# Altium schematic sheet Cyclone IV E.SchDoc
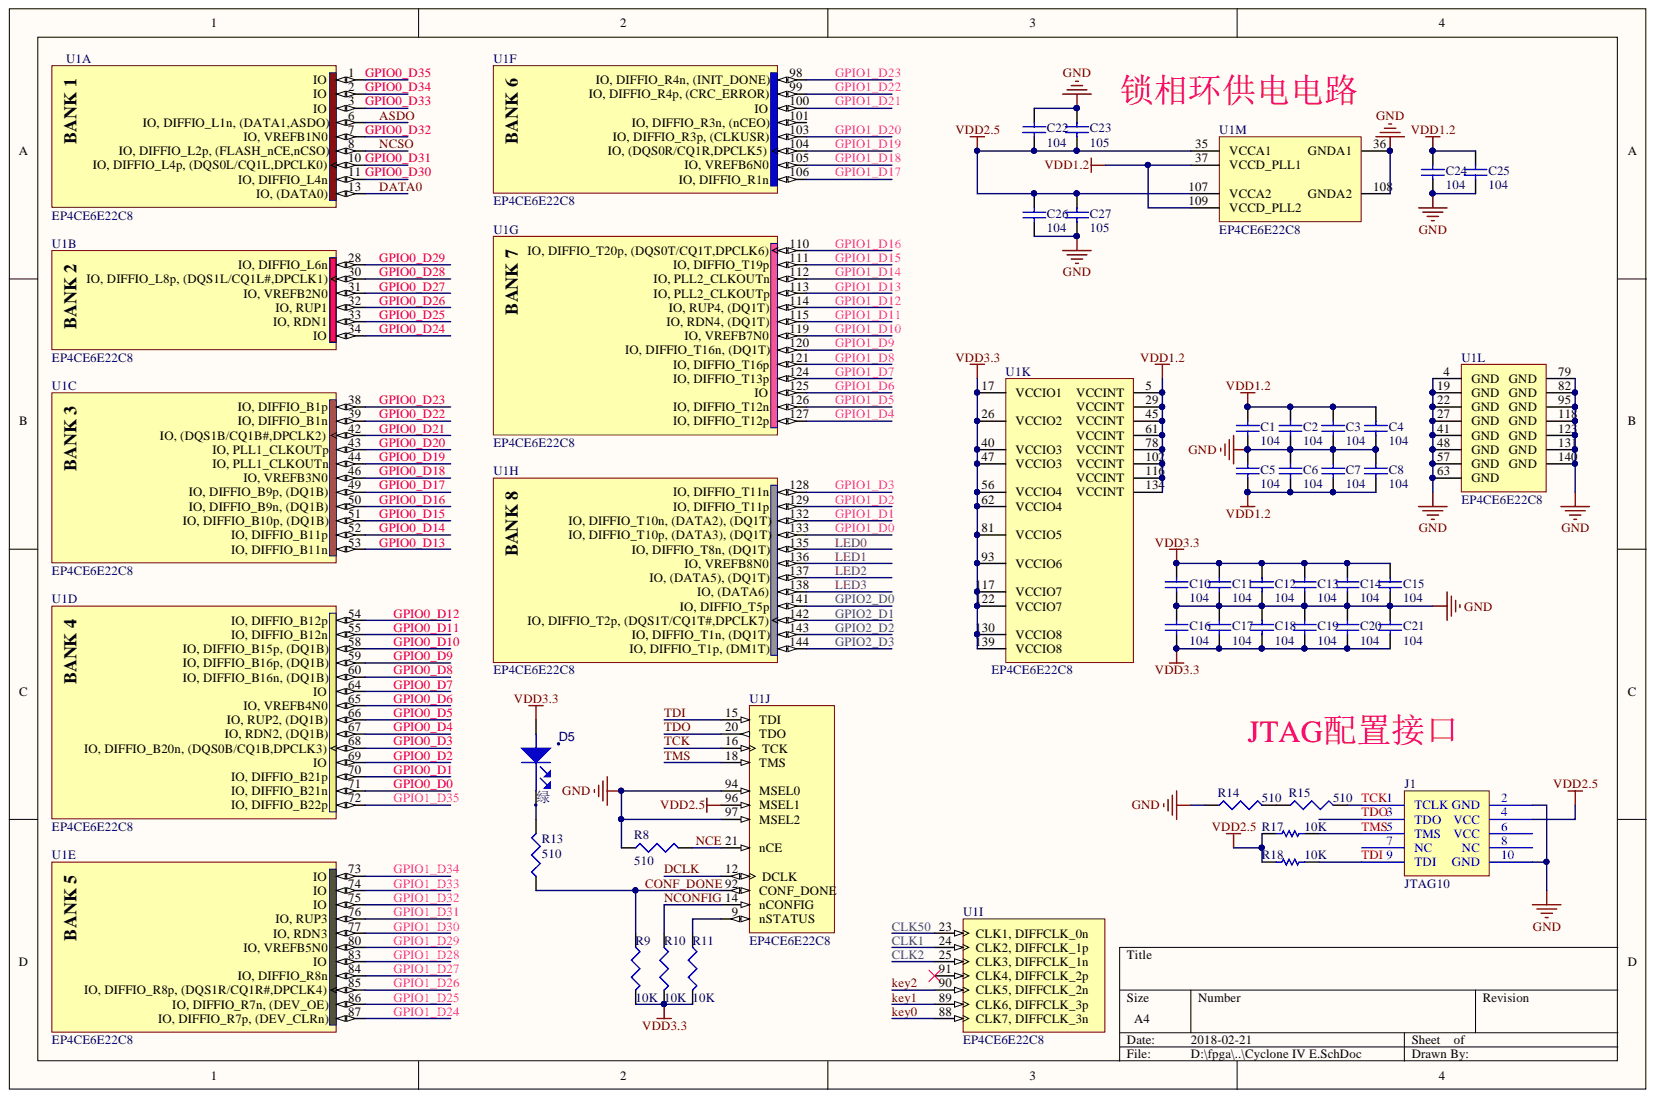
[diagram p0: Cyclone IV E.SchDoc]

## Parts
C1  104  [0603C]
C2  104  [0603C]
C3  104  [0603C]
C4  104  [0603C]
C5  104  [0603C]
C6  104  [0603C]
C7  104  [0603C]
C8  104  [0603C]
C10  104  [0603C]
C11  104  [0603C]
C12  104  [0603C]
C13  104  [0603C]
C14  104  [0603C]
C15  104  [0603C]
C16  104  [0603C]
C17  104  [0603C]
C18  104  [0603C]
C19  104  [0603C]
C20  104  [0603C]
C21  104  [0603C]
C22  104  [0603C]
C23  105  [0603C]
C24  104  [0603C]
C25  104  [0603C]
C26  104  [0603C]
C27  105  [0603C]
D5  绿  [0603 LED]
J1  JTAG10  [JTAG10]
R8  510  [0603R]
R9  10K  [0603R]
R10  10K  [0603R]
R11  10K  [0603R]
R13  510  [0603R]
R14  510  [0603R]
R15  510  [0603R]
R17  10K  [0603R]
R18  10K  [0603R]
U1  EP4CE6E22C8  [QFP_144(0.5PIN间距)]  (parts A,B,C,D,E,F,G,H,I,J,K,L,M)

## Nets
GND: C1.1 C2.1 C3.1 C4.1 C5.2 C6.2 C7.2 C8.2 C10.1 C11.1 C12.1 C13.1 C14.1 C15.1 C16.2 C17.2 C18.2 C19.2 C20.2 C21.2 C22.2 C23.2 C24.1 C25.1 C26.1 C27.1 J1.2(GND) J1.10(GND) R8.1 R14.1 U1.4(GND) U1.19(GND) U1.22(GND) U1.27(GND) U1.36(GNDA1) U1.41(GND) U1.48(GND) U1.57(GND) U1.63(GND) U1.79(GND) U1.82(GND) U1.94(MSEL0) U1.95(GND) U1.97(MSEL2) U1.108(GNDA2) U1.118(GND) U1.123(GND) U1.131(GND) U1.140(GND)
VDD1.2: C1.2 C2.2 C3.2 C4.2 C5.1 C6.1 C7.1 C8.1 C24.2 C25.2 U1.5(VCCINT) U1.29(VCCINT) U1.37(VCCD_PLL1) U1.45(VCCINT) U1.61(VCCINT) U1.78(VCCINT) U1.102(VCCINT) U1.109(VCCD_PLL2) U1.116(VCCINT) U1.134(VCCINT)
VDD2.5: C22.1 C23.1 C26.2 C27.2 J1.4(VCC) R17.1 R18.1 U1.35(VCCA1) U1.96(MSEL1) U1.107(VCCA2)
VDD3.3: C10.2 C11.2 C12.2 C13.2 C14.2 C15.2 C16.1 C17.1 C18.1 C19.1 C20.1 C21.1 D5.1 R9.2 R10.2 R11.2 U1.17(VCCIO1) U1.26(VCCIO2) U1.40(VCCIO3) U1.47(VCCIO3) U1.56(VCCIO4) U1.62(VCCIO4) U1.81(VCCIO5) U1.93(VCCIO6) U1.117(VCCIO7) U1.122(VCCIO7) U1.130(VCCIO8) U1.139(VCCIO8)
ASDO: U1.6(IO,_DIFFIO_L1n,_(DATA1,ASDO))
CLK1: U1.24(CLK2,_DIFFCLK_1p)
CLK2: U1.25(CLK3,_DIFFCLK_1n)
CLK50: U1.23(CLK1,_DIFFCLK_0n)
CONF_DONE: R9.1 R13.1 U1.92(CONF_DONE)
DATA0: U1.13(IO,_(DATA0))
DCLK: U1.12(DCLK)
GPIO0_D0: U1.71(IO,_DIFFIO_B21n)
GPIO0_D1: U1.70(IO,_DIFFIO_B21p)
GPIO0_D2: U1.69(IO)
GPIO0_D3: U1.68(IO,_DIFFIO_B20n,_(DQS0B/CQ1B,DPCLK3))
GPIO0_D4: U1.67(IO,_RDN2,_(DQ1B))
GPIO0_D5: U1.66(IO,_RUP2,_(DQ1B))
GPIO0_D6: U1.65(IO,_VREFB4N0)
GPIO0_D7: U1.64(IO)
GPIO0_D8: U1.60(IO,_DIFFIO_B16n,_(DQ1B))
GPIO0_D9: U1.59(IO,_DIFFIO_B16p,_(DQ1B))
GPIO0_D10: U1.58(IO,_DIFFIO_B15p,_(DQ1B))
GPIO0_D11: U1.55(IO,_DIFFIO_B12n)
GPIO0_D12: U1.54(IO,_DIFFIO_B12p)
GPIO0_D13: U1.53(IO,_DIFFIO_B11n)
GPIO0_D14: U1.52(IO,_DIFFIO_B11p)
GPIO0_D15: U1.51(IO,_DIFFIO_B10p,_(DQ1B))
GPIO0_D16: U1.50(IO,_DIFFIO_B9n,_(DQ1B))
GPIO0_D17: U1.49(IO,_DIFFIO_B9p,_(DQ1B))
GPIO0_D18: U1.46(IO,_VREFB3N0)
GPIO0_D19: U1.44(IO,_PLL1_CLKOUTn)
GPIO0_D20: U1.43(IO,_PLL1_CLKOUTp)
GPIO0_D21: U1.42(IO,_(DQS1B/CQ1B#,DPCLK2))
GPIO0_D22: U1.39(IO,_DIFFIO_B1n)
GPIO0_D23: U1.38(IO,_DIFFIO_B1p)
GPIO0_D24: U1.34(IO)
GPIO0_D25: U1.33(IO,_RDN1)
GPIO0_D26: U1.32(IO,_RUP1)
GPIO0_D27: U1.31(IO,_VREFB2N0)
GPIO0_D28: U1.30(IO,_DIFFIO_L8p,_(DQS1L/CQ1L#,DPCLK1))
GPIO0_D29: U1.28(IO,_DIFFIO_L6n)
GPIO0_D30: U1.11(IO,_DIFFIO_L4n)
GPIO0_D31: U1.10(IO,_DIFFIO_L4p,_(DQS0L/CQ1L,DPCLK0))
GPIO0_D32: U1.7(IO,_VREFB1N0)
GPIO0_D33: U1.3(IO)
GPIO0_D34: U1.2(IO)
GPIO0_D35: U1.1(IO)
GPIO1_D0: U1.133(IO,_DIFFIO_T10p,_(DATA3),_(DQ1T))
GPIO1_D1: U1.132(IO,_DIFFIO_T10n,_(DATA2),_(DQ1T))
GPIO1_D2: U1.129(IO,_DIFFIO_T11p)
GPIO1_D3: U1.128(IO,_DIFFIO_T11n)
GPIO1_D4: U1.127(IO,_DIFFIO_T12p)
GPIO1_D5: U1.126(IO,_DIFFIO_T12n)
GPIO1_D6: U1.125(IO)
GPIO1_D7: U1.124(IO,_DIFFIO_T13p)
GPIO1_D8: U1.121(IO,_DIFFIO_T16p)
GPIO1_D9: U1.120(IO,_DIFFIO_T16n,_(DQ1T))
GPIO1_D10: U1.119(IO,_VREFB7N0)
GPIO1_D11: U1.115(IO,_RDN4,_(DQ1T))
GPIO1_D12: U1.114(IO,_RUP4,_(DQ1T))
GPIO1_D13: U1.113(IO,_PLL2_CLKOUTp)
GPIO1_D14: U1.112(IO,_PLL2_CLKOUTn)
GPIO1_D15: U1.111(IO,_DIFFIO_T19p)
GPIO1_D16: U1.110(IO,_DIFFIO_T20p,_(DQS0T/CQ1T,DPCLK6))
GPIO1_D17: U1.106(IO,_DIFFIO_R1n)
GPIO1_D18: U1.105(IO,_VREFB6N0)
GPIO1_D19: U1.104(IO,_(DQS0R/CQ1R,DPCLK5))
GPIO1_D20: U1.103(IO,_DIFFIO_R3p,_(CLKUSR))
GPIO1_D21: U1.100(IO)
GPIO1_D22: U1.99(IO,_DIFFIO_R4p,_(CRC_ERROR))
GPIO1_D23: U1.98(IO,_DIFFIO_R4n,_(INIT_DONE))
GPIO1_D24: U1.87(IO,_DIFFIO_R7p,_(DEV_CLRn))
GPIO1_D25: U1.86(IO,_DIFFIO_R7n,_(DEV_OE))
GPIO1_D26: U1.85(IO,_DIFFIO_R8p,_(DQS1R/CQ1R#,DPCLK4))
GPIO1_D27: U1.84(IO,_DIFFIO_R8n)
GPIO1_D28: U1.83(IO)
GPIO1_D29: U1.80(IO,_VREFB5N0)
GPIO1_D30: U1.77(IO,_RDN3)
GPIO1_D31: U1.76(IO,_RUP3)
GPIO1_D32: U1.75(IO)
GPIO1_D33: U1.74(IO)
GPIO1_D34: U1.73(IO)
GPIO1_D35: U1.72(IO,_DIFFIO_B22p)
GPIO2_D0: U1.141(IO,_DIFFIO_T5p)
GPIO2_D1: U1.142(IO,_DIFFIO_T2p,_(DQS1T/CQ1T#,DPCLK7))
GPIO2_D2: U1.143(IO,_DIFFIO_T1n,_(DQ1T))
GPIO2_D3: U1.144(IO,_DIFFIO_T1p,_(DM1T))
KEY0: U1.88(CLK7,_DIFFCLK_3n)
KEY1: U1.89(CLK6,_DIFFCLK_3p)
KEY2: U1.90(CLK5,_DIFFCLK_2n)
LED0: U1.135(IO,_DIFFIO_T8n,_(DQ1T))
LED1: U1.136(IO,_VREFB8N0)
LED2: U1.137(IO,_(DATA5),_(DQ1T))
LED3: U1.138(IO,_(DATA6))
NCE: R8.2 U1.21(nCE)
NCONFIG: R10.1 U1.14(nCONFIG)
NCSO: U1.8(IO,_DIFFIO_L2p,_(FLASH_nCE,nCSO))
TCK: J1.1(TCLK) R15.2 U1.16(TCK)
TDI: J1.9(TDI) R18.2 U1.15(TDI)
TDO: J1.3(TDO) U1.20(TDO)
TMS: J1.5(TMS) R17.2 U1.18(TMS)
NetD5_2: D5.2 R13.2
NetR11_1: R11.1 U1.9(nSTATUS)
NetR14_2: R14.2 R15.1

Not connected: J1 6(VCC) 7(NC) 8(NC); U1 91(CLK4,_DIFFCLK_2p) 101(IO,_DIFFIO_R3n,_(nCEO))

## Library data of the parts
C1-C8 C10-C27 = Cap Semi: Capacitor (Semiconductor SIM Model); 贴片和直插: SMT; Component Kind: Standard; Library Name: NEW.SchLib; PackageDescription: Chip Capacitor; PackageReference: C0603
  C1-C8 C10-C22 C24-C26: Value: 104
  C23 C27: Value: 105
D5 = LED_Dup1: Value: LED_GREEN; 贴片和直插: SMT; Component Kind: Standard; PackageDescription: SM LED; 2 Flat Leads; PackageReference: 0603
J1 = JTAG10: Value: IDC10; 贴片和直插: DIP; Component Kind: Standard; PackageDescription: IDC10; PackageReference: IDC10; Library Name: MCA.SCHLIB
R8-R11 = RES: 贴片和直插: SMT; Component Kind: Standard; PackageDescription: Chip Resistor; PackageReference: R0603
  R8: Library Name: NEW.SchLib; Value: 510
  R9-R11: Library Name: MySchlib.SchLib; Value: 10K
R13-R15 = Res3: Resistor; 贴片和直插: SMT; Component Kind: Standard; Library Name: Miscellaneous Devices.IntLib; PackageDescription: Chip Resistor; PackageReference: R0603; Value: 510
R17 R18 = R0603: 贴片和直插: SMT; Component Kind: Standard; PackageDescription: Chip Resistor; PackageReference: R0603; Value: 10K; Library Name: MCA.SCHLIB
U1 = EP4CE6E22C8: Cyclone IV Family FPGA, 2V Core, 91 I/O Pins, 2 PLLs, 144-Pin QFP, Speed Grade 8, Commercial Grade; Value: EP4CE6E22C8N; 贴片和直插: SMT; Component Kind: Standard; Library Name: NEW.SchLib; PackageDescription: 144-Pin Plastic Enhanced Quad Flat Pack (EQFP144) - Wire Bond; PackageReference: EQFP144
